# Altium schematic sheet Encoder.SchDoc(Encoder)
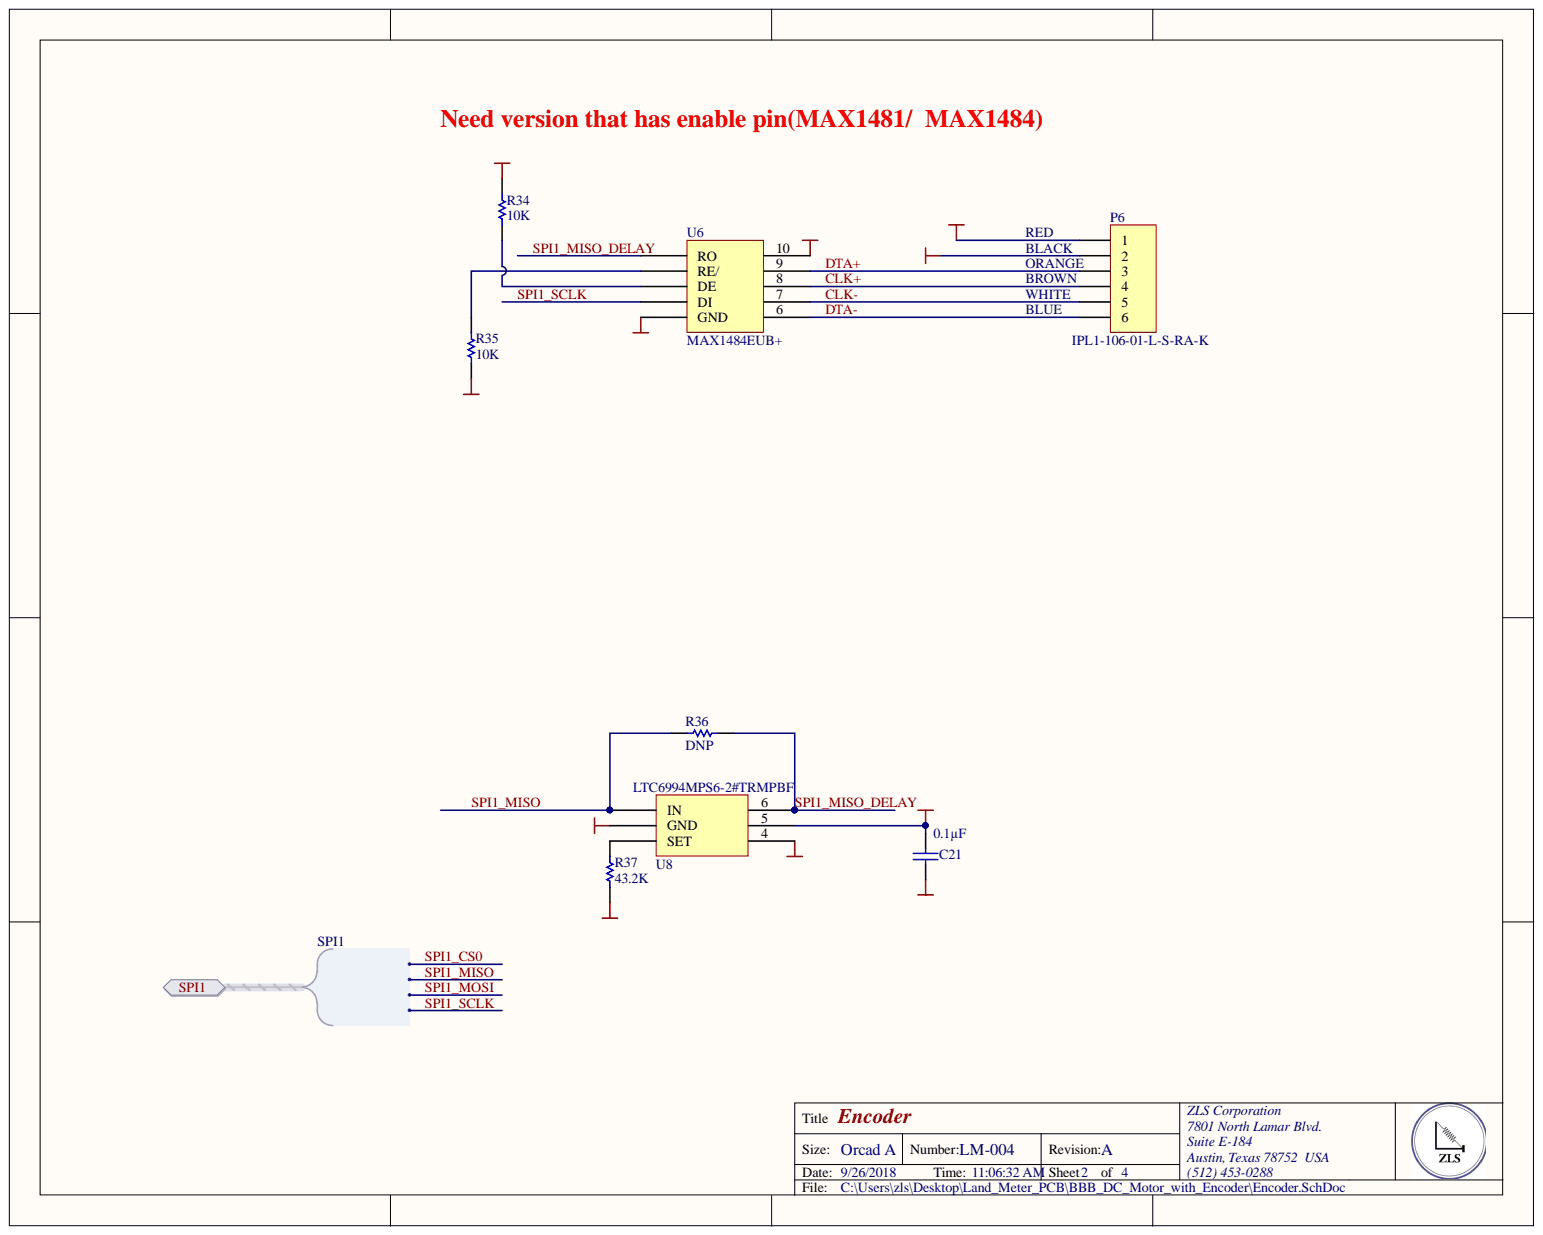
[diagram p0: Encoder.SchDoc]

## Parts
C21  Capacitance: 0.1µF  [CAPC0805(2012)145_N]  (hidden comment: CAP CER 0.1UF 25V X7R 0805)
P6  IPL1-106-01-L-S-RA-K  [IPL1-106-01-L-S-RA-K]
R34  10K  [RESC0805(2012)_L]
R35  10K  [RESC0805(2012)_L]
R36  DNP  [RESC0805(2012)_L]
R37  43.2K  [RESC0805(2012)_L]
U6  MAX1484EUB+  [MAX1486EUB+]
U8  LTC6994MPS6-2#TRMPBF  [LTC6994MPS6-2#TRMPBF]

## Ports
SPI1, SPI1.CS_Levels, SPI1.SPI1_MISO, SPI1.SPI1_MOSI, SPI1.SPI1_SCLK

## Nets
+3.3: C21.1 P6.1 R34.2 U6.10 U8.5
GND: C21.2 P6.2 R35.1 R37.2 U6.5(GND) U8.2(GND) U8.4
CLK+: P6.4 U6.8
CLK-: P6.5 U6.7
DTA+: P6.3 U6.9
DTA-: P6.6 U6.6
SPI1_MISO: R36.1 U8.1(IN) ; port SPI1
SPI1_MISO_DELAY: R36.2 U6.1(RO) U8.6
SPI1_SCLK: U6.4(DI) ; port SPI1
NetR34_1: R34.1 U6.3(DE)
NetR35_2: R35.2 U6.2(RE/)
NetR37_1: R37.1 U8.3(SET)
SPI1_CS0: ; port SPI1
SPI1_MOSI: ; port SPI1

## Library data of the parts
C21 = CMP-1036-04795-1: Manufacturer Part Number: GRM21BR71E104KA01L; Manufacturer: Murata Electronics North America; Tolerance: ±10%; Voltage - Rated: 25V; Thickness (Max): 0.053" (1.35mm); Temperature Coefficient: X7R; Supplier Part Number 1: 490-1673-6-ND; Supplier 1: Digi-Key; Stock 1: 891652; Size / Dimension: 0.079" L x 0.049" W (2.00mm x 1.25mm); RoHS: RoHS Compliant; Ratings: -; Pricing 1: 1=0.2, 10=0.145, 100=0.0683, 500=0.04788, 1000=0.03964 (USD); Part Status: Active; Packaging: Digi-Reel®; Package / Case: 0805 (2012 Metric); Operating Temperature: -55°C ~ 125°C; Mounting Type: Surface Mount, MLCC; Library Name: Altium Content Vault; Lead Style: -; Lead Spacing: -; Height - Seated (Max): -; Features: -; Description: CAP CER 0.1UF 25V X7R 0805; ComponentLink1URL: http://www.murata.com/~/media/webrenewal/support/library/catalog/products/capacitor/mlcc/c02e.ashx; ComponentLink1Description: http://www.murata.com/~/media/webrenewal/support/library/catalog/products/capacitor/mlcc/c02e.ashx; Component Kind: Standard; Category: Capacitors; Applications: General Purpose
P6 = Header 6: Header, 6-Pin; Manufacturer: Samtec Inc.; Voltage Rating: 675VAC, 954VDC; Style: Board to Cable/Wire; Shrouding: Shrouded - 4 Wall; Pitch - Mating: 0.100" (2.54mm); Number of Positions Loaded: All; Number of Positions: 6; Material Flammability Rating: UL94 V-0; Contact Length - Post: 0.085" (2.16mm); Contact Length - Mating: 0.215" (5.46mm); Termination: Solder; Supplier Part Number 1: SAM9602-ND; Supplier 1: Digi-Key; Stock 1: 6; Signal Integrity: Connector; Row Spacing - Mating: -; RoHS: RoHS Compliant; Pricing 1: 1=2.73, 34=2.39794, 102=2.18, 510=1.853, 1020=1.5805, 5032=1.417 (USD); Part Status: Active; Packaging: Tube; Overall Contact Length: -; Operating Temperature: -55°C ~ 125°C; Number of Rows: 1; Mounting Type: Through Hole, Right Angle; Mated Stacking Heights: -; Manufacturer Part Number: IPL1-106-01-L-S-RA-K; Library Name: Miscellaneous Connectors.IntLib; Insulation Material: Liquid Crystal Polymer (LCP); Insulation Height: 0.240" (6.10mm); Insulation Color: Natural; Ingress Protection: -; Features: -; Fastening Type: Locking Ramp; Current Rating: -; Contact Type: Male Pin; Contact Shape: Square; Contact Material: Phosphor Bronze; Contact Finish Thickness - Post: -; Contact Finish Thickness - Mating: 10.0µin (0.25µm); Contact Finish - Post: Tin; Contact Finish - Mating: Gold; Connector Type: Header; ComponentLink1URL: http://suddendocs.samtec.com/prints/ipl1-1xx-xx-xxx-s-xx-x-xx-mkt.pdf; ComponentLink1Description: http://suddendocs.samtec.com/prints/ipl1-1xx-xx-xxx-s-xx-x-xx-mkt.pdf; Component Kind: Standard; Category: Connectors, Interconnects; Applications: -
R34 R35 = CMP-1013-00215-1: RES SMD 10K OHM 1% 1/8W 0805; Manufacturer Part Number: CRCW080510K0FKEA; Manufacturer: Vishay Dale; Temperature Coefficient: ±100ppm/°C; Power (Watts): 0.125W, 1/8W; Tolerance: ±1%; Supplier Part Number 1: 541-10.0KCCT-ND; Supplier Device Package: 0805; Supplier 1: Digi-Key; Stock 1: 1104982; Size / Dimension: 0.079" L x 0.049" W (2.00mm x 1.25mm); RoHS: RoHS Compliant; Pricing 1: 1=0.1, 10=0.055, 100=0.0223, 1000=0.01003, 2500=0.0087 (USD); Part Status: Active; Packaging: Cut Tape (CT); Package / Case: 0805 (2012 Metric); Operating Temperature: -55°C ~ 155°C; Library Name: Altium Content Vault; Height - Seated (Max): 0.020" (0.50mm); Features: Automotive AEC-Q200; Failure Rate: -; Composition: Thick Film; ComponentLink1URL: http://www.vishay.com/docs/20035/dcrcwe3.pdf; ComponentLink1Description: http://www.vishay.com/docs/20035/dcrcwe3.pdf; Component Kind: Standard; Category: Resistors
R36 = CMP-1013-00215-1: RES SMD 0 OHM 5% 1/8W 0805; Library Name: Altium Content Vault; Component Kind: Standard
R37 = CMP-1013-00215-1: RES SMD 43.2K OHM 1% 1/8W 0805; Manufacturer Part Number: CRCW080543K2FKEA; Manufacturer: Vishay Dale; Temperature Coefficient: ±100ppm/°C; Power (Watts): 0.125W, 1/8W; Tolerance: ±1%; Supplier Part Number 1: 541-43.2KCCT-ND; Supplier Device Package: 0805; Supplier 1: Digi-Key; Stock 1: 68577; Size / Dimension: 0.079" L x 0.049" W (2.00mm x 1.25mm); RoHS: RoHS Compliant; Pricing 1: 1=0.1, 10=0.055, 100=0.0223, 1000=0.01003, 2500=0.0087 (USD); Part Status: Active; Packaging: Cut Tape (CT); Package / Case: 0805 (2012 Metric); Operating Temperature: -55°C ~ 155°C; Library Name: Altium Content Vault; Height - Seated (Max): 0.020" (0.50mm); Features: Automotive AEC-Q200; Failure Rate: -; Composition: Thick Film; ComponentLink1URL: http://www.vishay.com/docs/20035/dcrcwe3.pdf; ComponentLink1Description: http://www.vishay.com/docs/20035/dcrcwe3.pdf; Component Kind: Standard; Category: Resistors
U6 = MAX1484EUB+: IC TXRX RS485/RS422 10-UMAX; Manufacturer: Maxim Integrated; Voltage - Supply: 4.75 V ~ 5.25 V; Protocol: RS422, RS485; Number of Drivers/Receivers: 1/1; Duplex: Full; Data Rate: 12Mbps; Type: Transceiver; Supplier Part Number 1: MAX1484EUB+-ND; Supplier Device Package: 10-uMAX; Supplier 1: Digi-Key; Stock 1: 1906; RoHS: RoHS Compliant; Pricing 1: 1=2.57, 10=2.442, 25=2.28, 50=2.28 (USD); Part Status: Active; Packaging: Tube; Package / Case: 10-TFSOP, 10-MSOP (0.118", 3.00mm Width); Operating Temperature: -40°C ~ 85°C; Mounting Type: Surface Mount; Manufacturer Part Number: MAX1484EUB+; ComponentLink1URL: https://datasheets.maximintegrated.com/en/ds/MAX1481-MAX1486.pdf; ComponentLink1Description: https://datasheets.maximintegrated.com/en/ds/MAX1481-MAX1486.pdf; Component Kind: Standard; Category: Integrated Circuits (ICs); Base Part Number: MAX1484; Library Name: ZLS_Schematic_lib.SchLib
U8 = LTC6994MPS6-2#TRMPBF: Manufacturer Part Number: LTC6994CS6-2#TRMPBF; Manufacturer: Linear Technology/Analog Devices; Voltage - Supply: 2.25 V ~ 5.5 V; Function: Programmable; Available Total Delays: 1µs ~ 33.6s; Supplier Part Number 1: LTC6994CS6-2#TRMPBFCT-ND; Supplier Device Package: TSOT-23-6; Supplier 1: Digi-Key; Stock 1: 1401; RoHS: RoHS Compliant; Pricing 1: 1=3.42, 25=3.0496, 100=1.9217, 250=1.76156 (USD); Part Status: Active; Packaging: Cut Tape (CT); Package / Case: SOT-23-6 Thin, TSOT-23-6; Operating Temperature: 0°C ~ 70°C; Mounting Type: Surface Mount; Delay to 1st Tap: -; ComponentLink1URL: http://www.linear.com/docs/29725; ComponentLink1Description: http://www.linear.com/docs/29725; Component Kind: Standard; Category: Integrated Circuits (ICs); Base Part Number: LTC6994; Library Name: ZLS_Schematic_lib.SchLib
